# Altium schematic sheet Sch-QWA59_HDMI.SchDoc
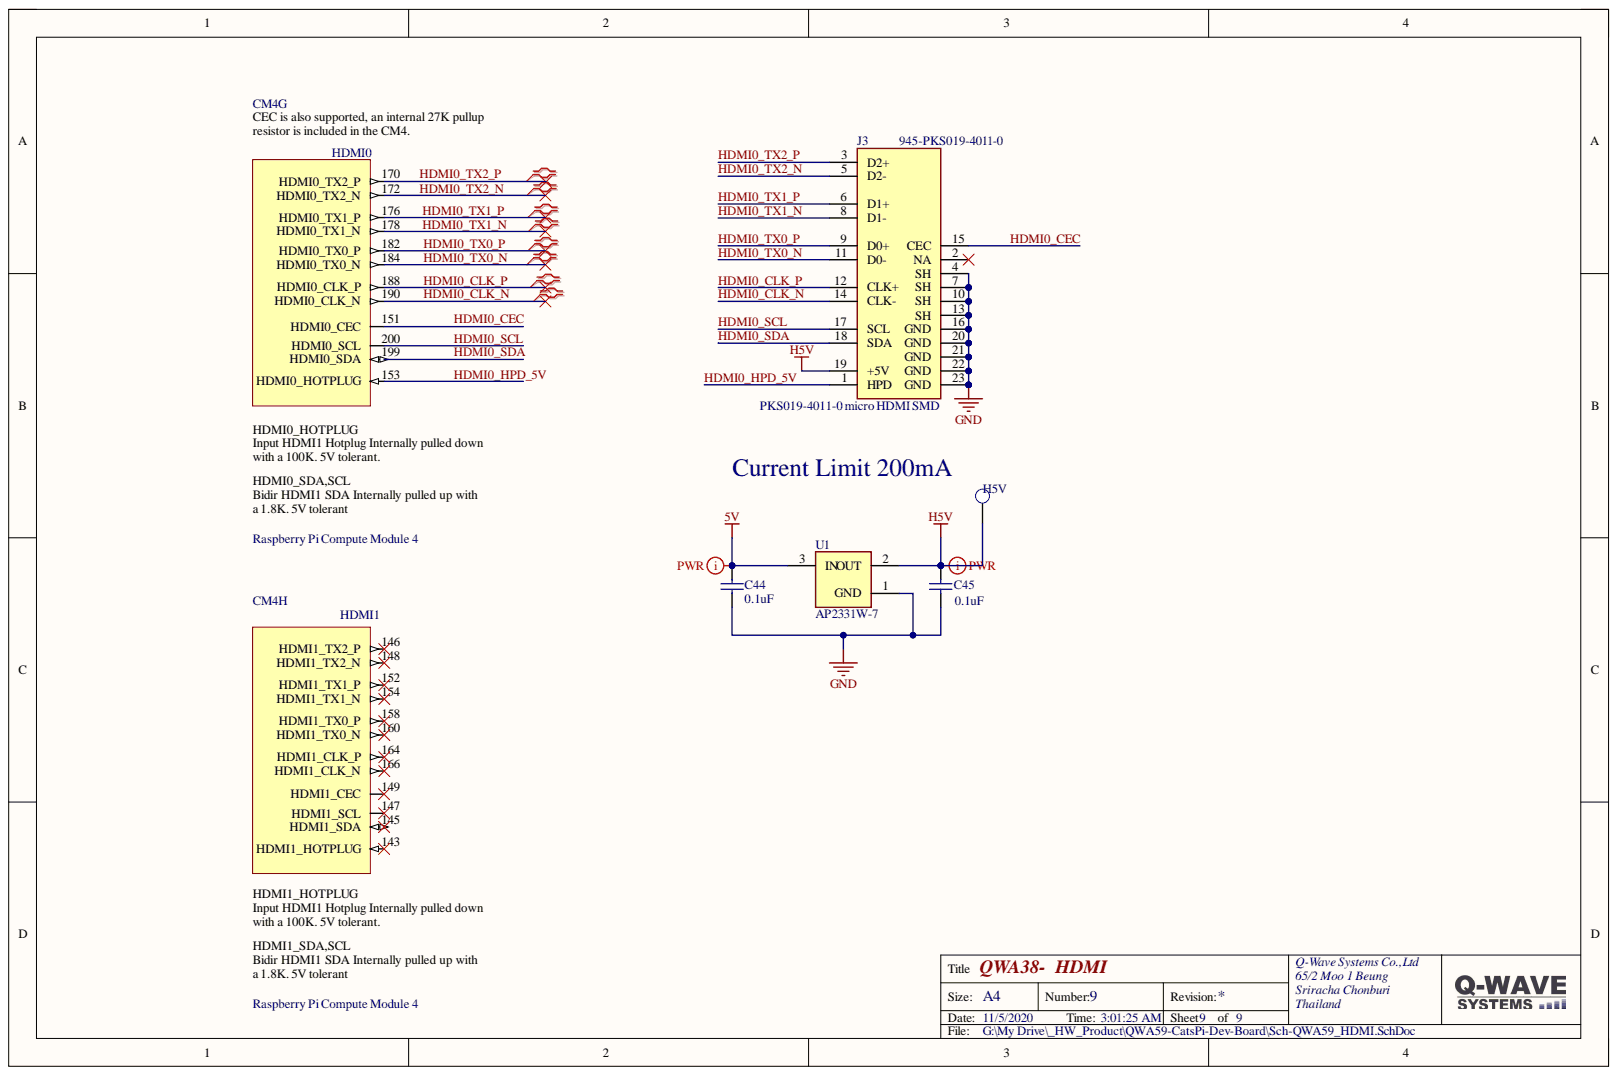
[diagram p0: Sch-QWA59_HDMI.SchDoc]

## Parts
C44  0.1uF  [CAP_0603]
C45  0.1uF  [CAP_0603]
CM4  Raspberry Pi Compute Module 4  [CM4-F40C-100DS-0.4v_1.5mm_0mm]  (parts G,H)
H5V  H5V  [TEST_POINT]
J3  PKS019-4011-0 micro HDMI SMD  Mouser Part Number: 945-PKS019-4011-0  [PKS01940110]
U1  Part Number: AP2331W-7  [Diodes_AP2331W-7_0]  (hidden comment: IC_Diodes_AP2331W-7_eec)

## Nets
5V: C44.2 U1.3
GND: C44.1 C45.1 J3.4(SH) J3.7(SH) J3.10(SH) J3.13(SH) J3.16(GND) J3.20(GND) J3.21(GND) J3.22(GND) J3.23(GND) U1.1
H5V: C45.2 H5V.1 J3.19(+5V) U1.2
HDMI0_CEC: CM4.151(HDMI0_CEC) J3.15(CEC)
HDMI0_CLK_N: CM4.190(HDMI0_CLK_N) J3.14(CLK-)
HDMI0_CLK_P: CM4.188(HDMI0_CLK_P) J3.12(CLK+)
HDMI0_HPD_5V: CM4.153(HDMI0_HOTPLUG) J3.1(HPD)
HDMI0_SCL: CM4.200(HDMI0_SCL) J3.17(SCL)
HDMI0_SDA: CM4.199(HDMI0_SDA) J3.18(SDA)
HDMI0_TX0_N: CM4.184(HDMI0_TX0_N) J3.11(D0-)
HDMI0_TX0_P: CM4.182(HDMI0_TX0_P) J3.9(D0+)
HDMI0_TX1_N: CM4.178(HDMI0_TX1_N) J3.8(D1-)
HDMI0_TX1_P: CM4.176(HDMI0_TX1_P) J3.6(D1+)
HDMI0_TX2_N: CM4.172(HDMI0_TX2_N) J3.5(D2-)
HDMI0_TX2_P: CM4.170(HDMI0_TX2_P) J3.3(D2+)

Not connected: CM4 143(HDMI1_HOTPLUG) 145(HDMI1_SDA) 146(HDMI1_TX2_P) 147(HDMI1_SCL) 148(HDMI1_TX2_N) 149(HDMI1_CEC) 152(HDMI1_TX1_P) 154(HDMI1_TX1_N) 158(HDMI1_TX0_P) 160(HDMI1_TX0_N) 164(HDMI1_CLK_P) 166(HDMI1_CLK_N); J3 2(NA)

## Library data of the parts
C44 C45 = Cap Semi: 0.1µF ±5% 50V Ceramic Capacitor X7R 0603; MFG: KEMET; MFG P/N: C0603C104J5RACTU; Component Kind: Standard; Library Name: Miscellaneous Devices.IntLib; Signal Integrity: Cap Semi; Simulation: CAP
CM4 = CM4: Raspberry Pi Compute Module 4 by Amornthep Phunsin; Component Kind: Standard; Library Name: CM4.SchLib
H5V = TEST_POINT: Test Point; Component Kind: Standard; Library Name: Misc.SCHLIB
J3 = PKS019-4011-0: micro HDMI SMD; Manufacturer_Name: Yamaichi; Arrow Part Number: PKS019-4011-0; Component Kind: Standard; Datasheet Link: https://www.mouser.com/catalog/specsheets/PKS019-4011-0%20Drawing.pdf; Height: 3.27mm; Library Name: QWA36-Schematic-Lib.SchLib; Manufacturer_Part_Number: PKS019-4011-0; Mouser Price/Stock: https://www.mouser.co.uk/ProductDetail/Yamaichi-Electronics/PKS019-4011-0?qs=6DDkx98%252BHnB4M72oyP%252BmZw%3D%3D; Supplier 1: Mouser; Supplier Part Number 1: 945-PKS019-4011-0
U1 = IC_Diodes_AP2331W-7_eec: IC LOAD SWITCH SC59; Manufacturer: Diodes; Component Kind: Standard; ComponentLink1Description: Upverter; ComponentLink1URL: https://upverter.com/upn/revision/7e2e43c6fc93a657/; ComponentLink2Description: Datasheet; ComponentLink2URL: http://www.diodes.com/_files/datasheets/AP2331.pdf; Digikey Description: IC LOAD SWITCH SC59; Digikey Part Number: AP2331W-7DICT-ND; helpURL: https://upverter.com/upn/revision/7e2e43c6fc93a657/; Lead Free: yes; Library Name: IC_Diodes_AP2331W-7_eec.SchLib; Mouser Part Number: 621-AP2331W-7; Package: SC-59; Price: 0.244736178545; RoHS: TRUE; Supplier 1: Digi-Key; Supplier 2: Mouser; Supplier Part Number 1: AP2331W-7DICT-ND; Supplier Part Number 2: 621-AP2331W-7; Temperature: -40°C to +85°C; Temperature Range High: +85°C; Temperature Range Low: -40°C
